# Altium schematic sheet [06] PD_BB_Out.SchDoc("[06] PD_BB_Out")
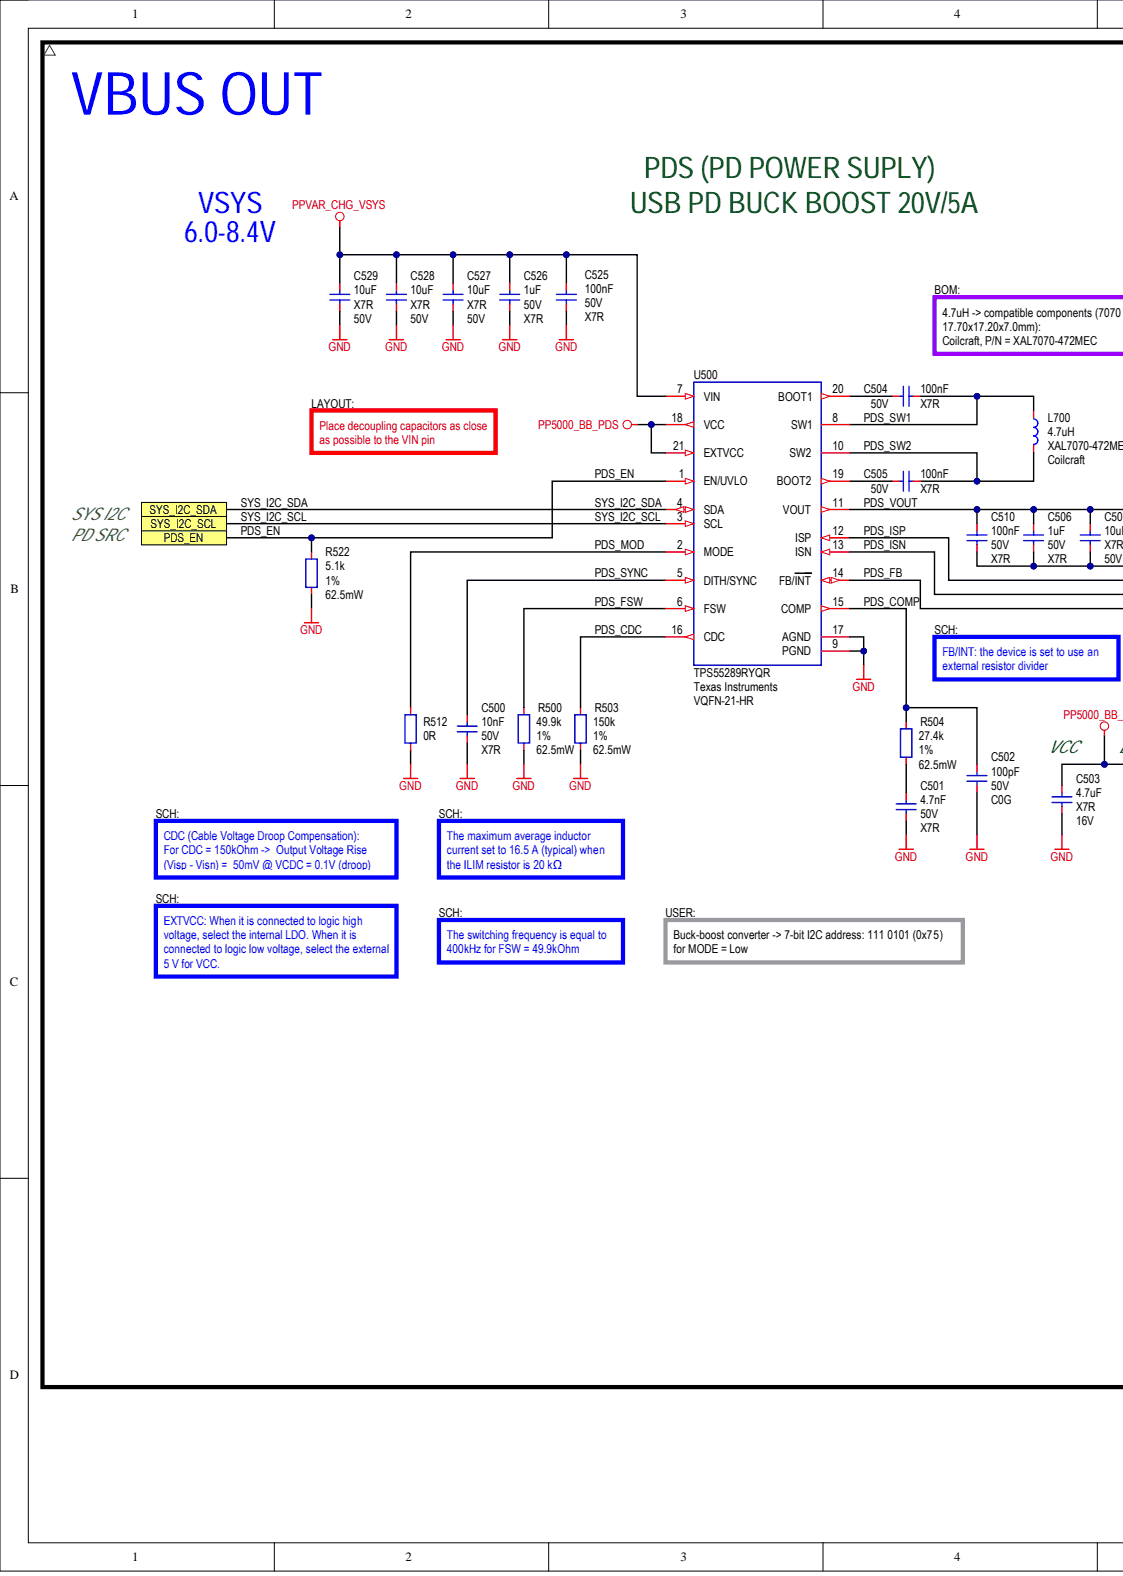
[diagram p0: [06] PD_BB_Out.SchDoc, left]
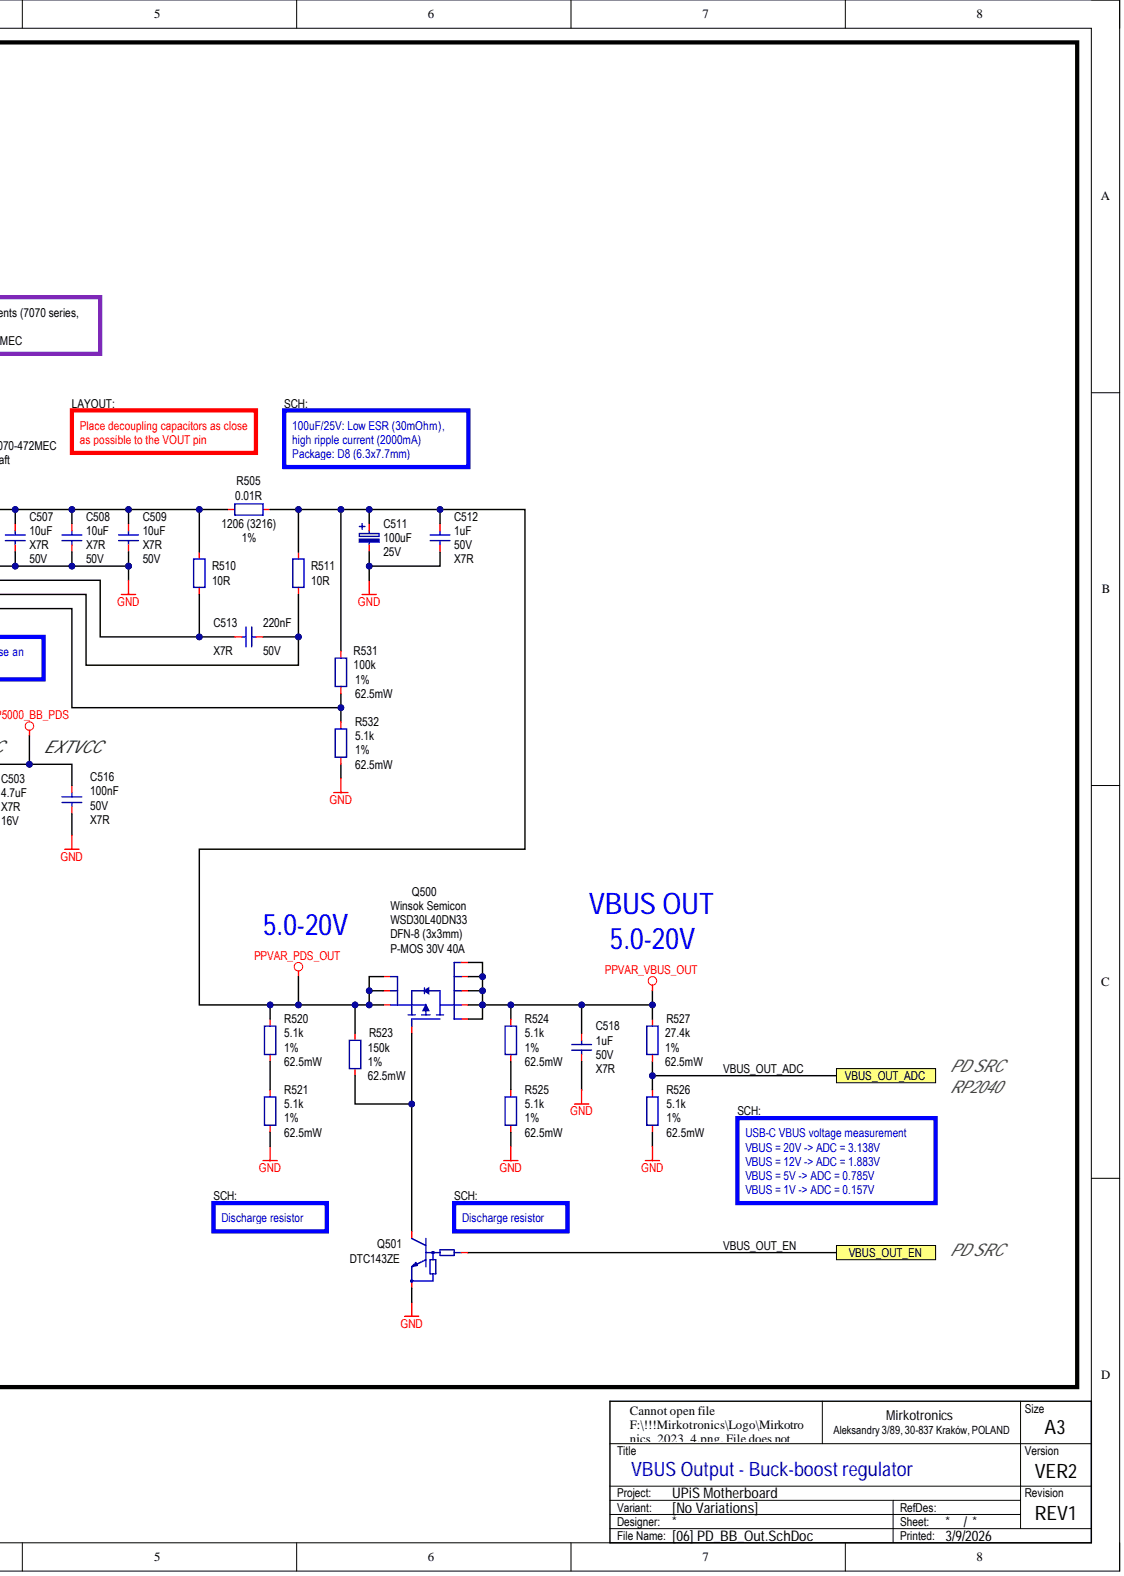
[diagram p1: [06] PD_BB_Out.SchDoc, right]

## Parts
C500  10nF  Dielectric: X7R  Voltage Rating: 50V
C501  4.7nF  Dielectric: X7R  Voltage Rating: 50V
C502  100pF  Dielectric: C0G  Voltage Rating: 50V
C503  4.7uF  Dielectric: X7R  Voltage Rating: 16V
C504  100nF  Dielectric: X7R  Voltage Rating: 50V
C505  100nF  Dielectric: X7R  Voltage Rating: 50V
C506  1uF  Dielectric: X7R  Voltage Rating: 50V
C507  10uF  Dielectric: X7R  Voltage Rating: 50V
C508  10uF  Dielectric: X7R  Voltage Rating: 50V
C509  10uF  Dielectric: X7R  Voltage Rating: 50V
C510  100nF  Dielectric: X7R  Voltage Rating: 50V
C511  100uF  Voltage Rating: 25V
C512  1uF  Dielectric: X7R  Voltage Rating: 50V
C513  220nF  Dielectric: X7R  Voltage Rating: 50V
C516  100nF  Dielectric: X7R  Voltage Rating: 50V
C518  1uF  Dielectric: X7R  Voltage Rating: 50V
C525  100nF  Dielectric: X7R  Voltage Rating: 50V
C526  1uF  Dielectric: X7R  Voltage Rating: 50V
C527  10uF  Dielectric: X7R  Voltage Rating: 50V
C528  10uF  Dielectric: X7R  Voltage Rating: 50V
C529  10uF  Dielectric: X7R  Voltage Rating: 50V
L700  4.7uH  Manufacturer: Coilcraft  MPN: XAL7070-472MEC
Q500  P-MOS 30V 40A  Package (Case): DFN-8 (3x3mm)
Q501  DTC143ZE
R500  49.9k  Tolerance: 1%
R503  150k  Power Rating: 62.5mW  Tolerance: 1%
R504  27.4k  Power Rating: 62.5mW  Tolerance: 1%
R505  0.01R  Package (Case): 1206 (3216)  Tolerance: 1%
R510  10R
R511  10R
R512  0R
R520  5.1k  Power Rating: 62.5mW  Tolerance: 1%
R521  5.1k  Power Rating: 62.5mW  Tolerance: 1%
R522  5.1k  Power Rating: 62.5mW  Tolerance: 1%
R523  150k  Power Rating: 62.5mW  Tolerance: 1%
R524  5.1k  Power Rating: 62.5mW  Tolerance: 1%
R525  5.1k  Power Rating: 62.5mW  Tolerance: 1%
R526  5.1k  Power Rating: 62.5mW  Tolerance: 1%
R527  27.4k  Power Rating: 62.5mW  Tolerance: 1%
R531  100k  Power Rating: 62.5mW  Tolerance: 1%
R532  5.1k  Power Rating: 62.5mW  Tolerance: 1%
U500  TPS55289  Manufacturer: Texas Instruments  MPN: TPS55289RYQR  Package (Case): VQFN-21-HR

## Ports
PDS_EN, SYS_I2C_SCL, SYS_I2C_SDA, VBUS_OUT_ADC, VBUS_OUT_EN

## Nets
GND: C500.1 C501.1 C502.1 C503.1 C506.1 C507.1 C508.1 C509.1 C510.1 C511.1 C512.1 C516.2 C518.1 C525.2 C526.1 C527.1 C528.1 C529.1 Q501.2 R500.2 R503.2 R512.1 R521.1 R522.1 R525.1 R526.1 R532.1 U500.9(PGND) U500.17(AGND)
PP5000_BB_PDS: C503.2 C516.1 U500.18(VCC) U500.21(EXTVCC)
PPVAR_CHG_VSYS: C525.1 C526.2 C527.2 C528.2 C529.2 U500.7(VIN)
PPVAR_PDS_OUT: C511.2 C512.2 Q500.1 Q500.2 Q500.3 R505.2 R511.1 R520.2 R523.1 R531.2
PPVAR_VBUS_OUT: C518.2 Q500.5 Q500.6 Q500.7 Q500.8 Q500.EP R524.2 R527.1
PDS_CDC: R503.1 U500.16(CDC)
PDS_COMP: C502.2 R504.1 U500.15(COMP)
PDS_EN: R522.2 U500.1(EN/UVLO) ; port PDS_EN
PDS_FB: R531.1 R532.2 U500.14(FB/INT)
PDS_FSW: R500.1 U500.6(FSW)
PDS_ISN: C513.1 R511.2 U500.13(ISN)
PDS_ISP: C513.2 R510.2 U500.12(ISP)
PDS_MOD: R512.2 U500.2(MODE)
PDS_SW1: C504.1 L700.1 U500.8(SW1)
PDS_SW2: C505.1 L700.2 U500.10(SW2)
PDS_SYNC: C500.2 U500.5(DITH/SYNC)
PDS_VOUT: C506.2 C507.2 C508.2 C509.2 C510.2 R505.1 R510.1 U500.11(VOUT)
SYS_I2C_SCL: U500.3(SCL) ; port SYS_I2C_SCL
SYS_I2C_SDA: U500.4(SDA) ; port SYS_I2C_SDA
VBUS_OUT_ADC: R526.2 R527.2 ; port VBUS_OUT_ADC
VBUS_OUT_EN: Q501.1 ; port VBUS_OUT_EN
NetC501_2: C501.2 R504.2
NetC504_2: C504.2 U500.20(BOOT1)
NetC505_2: C505.2 U500.19(BOOT2)
NetQ500_4: Q500.4 Q501.3 R523.2
NetR520_1: R520.1 R521.2
NetR524_1: R524.1 R525.2

## Library data of the parts
C500 = CAP-0023: Capacitor; MLCC; GENERIC; TBD; TBD; TBD; TBD; SMD; 0603 (1608);; Manufacturer: YAGEO; MPN: CC0402KRX7R9BB103; Database Table Name: CAPACITOR$; Package (Case): 0402 (1005); Tolerance: 10%; Capacitance: 10nF; Component Kind: Standard; Component Subtype: MLCC; Component Type: Capacitor; Mounting Type: SMD; Operating Temperature: TBD; Supplier 1: LCSC; Supplier 2: Mouser; Supplier 3: DigiKey; Supplier Part Number 1: C60133; Value: 10nF; Library Name: MirFol_db_local.DbLib
C501 = CAP-0023: Capacitor; MLCC; GENERIC; TBD; TBD; TBD; TBD; SMD; 0603 (1608);; Manufacturer: YAGEO; MPN: CC0402KRX7R9BB472; Database Table Name: CAPACITOR$; Package (Case): 0402 (1005); Tolerance: 10%; Capacitance: 4.7nF; Component Kind: Standard; Component Subtype: MLCC; Component Type: Capacitor; Mounting Type: SMD; Operating Temperature: TBD; Supplier 1: LCSC; Supplier 2: Mouser; Supplier 3: DigiKey; Supplier Part Number 1: C106208; Value: 4.7nF; Library Name: MirFol_db_local.DbLib
C502 = CAP-0023: Capacitor; MLCC; GENERIC; TBD; TBD; TBD; TBD; SMD; 0603 (1608);; Manufacturer: Murata Electronics; MPN: GRM1555C1H101JA01D; Database Table Name: CAPACITOR$; Package (Case): 0402 (1005); Tolerance: 5%; Capacitance: 100pF; Component Kind: Standard; Component Subtype: MLCC; Component Type: Capacitor; Mounting Type: SMD; Operating Temperature: TBD; Supplier 1: LCSC; Supplier 2: Mouser; Supplier 3: DigiKey; Supplier Part Number 1: C77177; Value: 100pF; Library Name: MirFol_db_local.DbLib
C503 = CAP-0023: Capacitor; MLCC; GENERIC; TBD; TBD; TBD; TBD; SMD; 0603 (1608);; Manufacturer: Murata Electronics; MPN: GRM188Z71C475KE21D; Component Body: 1.60 x 0.80mm; Database Table Name: CAPACITOR$; Packaging Type: Tape & Reel; Tolerance: 10%; Capacitance: 4.7uF; Component Height: 0.80mm; Component Kind: Standard; Component Subtype: MLCC; Component Type: Capacitor; Features: Standard; ID: CAP-0010; Mounting Type: SMD; Operating Temperature: -55°C to +125°C; Package (Case): 0603 (1608); ROHS Status: RoHS Compliant; Supplier 1: LCSC; Supplier 2: Mouser; Supplier 3: Digikey; Supplier Part Number 1: C389010; Value: 4.7uF; Library Name: MirFol_db_local.DbLib
C504 C505 C510 C516 C525 = CAP-0004: Capacitor; MLCC; 100nF; 16VDC; X7R; 10%; Standard; SMD; 0402 (1005);; Manufacturer: Murata Electronics; MPN: GRM155R71H104KE14D; Component Body: 1.00 x 0.50mm; Database Table Name: CAPACITOR$; Packaging Type: Tape & Reel; Capacitance: 100nF; Component Height: 0.50mm; Component Kind: Standard; Component Subtype: MLCC; Component Type: Capacitor; Features: Standard; ID: CAP-0004; Mounting Type: SMD; Operating Temperature: -55°C to +125°C; Package (Case): 0402 (1005); ROHS Status: RoHS Compliant; Supplier 1: LCSC; Supplier 2: Mouser; Supplier 3: DigiKey; Supplier Part Number 1: C77020; Tolerance: 10%; Value: 100nF; Library Name: MirFol_db_local.DbLib
C506 C512 C518 C526 = CAP-0024: Capacitor; MLCC; GENERIC; TBD; TBD; TBD; TBD; SMD; 0805 (2012);; Manufacturer: Samsung Electro-Mechanics; MPN: CL21B105KBFNNNE; Database Table Name: CAPACITOR$; Tolerance: 10%; Capacitance: 1uF; Component Body: TBD; Component Height: TBD; Component Kind: Standard; Component Subtype: MLCC; Component Type: Capacitor; Features: TBD; ID: CAP-0024; Mounting Type: SMD; Operating Temperature: -55°C to 125°C; Package (Case): 0805 (2012); Packaging Type: TBD; ROHS Status: TBD; Supplier 1: LCSC; Supplier 2: Mouser; Supplier 3: Farnell; Supplier Part Number 1: C28323; Supplier Part Number 2: 187-CL21B105KBFNNNE; Supplier Part Number 3: 3013482; Value: 1uF; Library Name: MirFol_db_local.DbLib
C507-C509 C527-C529 = CAP-0025: Capacitor; MLCC; GENERIC; TBD; TBD; TBD; TBD; SMD; 1206 (3216);; Manufacturer: Samsung Electro-Mechanics; MPN: CL31B106KBHNNNE; Database Table Name: CAPACITOR$; Tolerance: 10%; Capacitance: 10uF; Component Body: TBD; Component Height: TBD; Component Kind: Standard; Component Subtype: MLCC; Component Type: Capacitor; Features: TBD; ID: CAP-0016; Mounting Type: SMD; Operating Temperature: TBD; Package (Case): 1206 (3216); Packaging Type: TBD; ROHS Status: TBD; Supplier 1: LCSC; Supplier 2: Mouser; Supplier 3: DigiKey; Supplier Part Number 1: C89632; Value: 10uF; Library Name: MirFol_db_local.DbLib
C511 = CAP_POL_2P_NP: Capacitor; Polarized;; Manufacturer: Panasonic; MPN: EEH-ZA1E101XP; Component Subtype: Electrolytic; Package (Case): SMD D8 (6.3x7.7mm); Capacitance: 100uF; Component Kind: Standard; Component Type: Capacitor; Mounting Type: SMD; Supplier 1: LCSC; Supplier 2: Mouser; Supplier Part Number 1: C264047; Supplier Part Number 2: 667-EEH-ZA1E101XP; Value: 100uF; Library Name: MirFol_lib.SchLib
C513 = CAP-0023: Capacitor; MLCC; GENERIC; TBD; TBD; TBD; TBD; SMD; 0603 (1608);; Manufacturer: CCTC; MPN: TCC0603X7R224K500CT; Component Body: 1.60 x 0.80mm; Database Table Name: CAPACITOR$; Packaging Type: Tape & Reel; Tolerance: 10%; Capacitance: 220nF; Component Height: 0.80mm; Component Kind: Standard; Component Subtype: MLCC; Component Type: Capacitor; Features: Standard; ID: CAP-0010; Mounting Type: SMD; Operating Temperature: -55°C to +125°C; Package (Case): 0603 (1608); ROHS Status: RoHS Compliant; Supplier 1: LCSC; Supplier 2: Mouser; Supplier 3: Digikey; Supplier Part Number 1: C344195; Value: 220nF; Library Name: MirFol_db_local.DbLib
L700 = IND: Inductor;; Component Subtype: Power Inductor; Package (Case): SMD; Component Kind: Standard; Component Type: Inductor; Mounting Type: SMD; Supplier 1: LCSC; Supplier 2: Mouser; Supplier 3: DigiKey; Supplier Part Number 1: C3911513; Value: 4.7uH; Library Name: MirFol_lib.SchLib
Q500 = TRA_PMOS_SSSGDDDD_EP: Transistor; PMOS;; Manufacturer: Winsok Semicon; MPN: WSD30L40DN33; Component Subtype: P-MOSFET; Component Kind: Standard; Component Type: Transistor; Mounting Type: SMD; Supplier 1: LCSC; Supplier 2: Mouser; Supplier 3: Digikey; Supplier Part Number 1: C148390; Value: P-MOS 30V 40A; Library Name: Pineberry_Lib.SchLib
Q501 = TRA_BJT_NPN_DIG_BEC: Transistor; BJT; NPN; Digital;; Manufacturer: Jiangsu Changjing Electronics Technology Co., Ltd.; Component Subtype: NPN Transistor; Package (Case): SOT-523; Component Kind: Standard; Component Type: Transistor; Mounting Type: SMD; MPN: DTC143ZE; Supplier 1: LCSC; Supplier 2: Mouser; Supplier 3: DigiKey; Supplier Part Number 1: C78593; Value: DTC143ZE; Library Name: MirFol_lib.SchLib
R500 = RES-0003: Resistor; Thick Film; 1k; 1%; 62.5mW; 100PPM; 50V; Standard; SMD; 0402 (1005);; Manufacturer: Yageo Corporation; MPN: RC0402FR-0749K9L; Component Body: 1.00 x 0.50mm; Database Table Name: RESISTOR$; Packaging Type: Tape & Reel; Component Height: 0.35mm; Component Kind: Standard; Component Subtype: Thick Film; Component Type: Resistor; Features: Standard; ID: RES-0003; Mounting Type: SMD; Operating Temperature: -55°C to +155°C; Package (Case): 0402 (1005); Power Rating: 62.5mW; Resistance: 49.9k; ROHS Status: RoHS Compliant; Supplier 1: LCSC; Supplier 2: Mouser; Supplier 3: Digikey; Supplier Part Number 1: C137969; TCR: 100PPM; Value: 49.9k; Voltage Rating: 50V; Library Name: MirFol_db_local.DbLib
R503 R523 = RES-0003: Resistor; Thick Film; 1k; 1%; 62.5mW; 100PPM; 50V; Standard; SMD; 0402 (1005);; Manufacturer: Yageo Corporation; MPN: RC0402FR-07150KL; Component Body: 1.00 x 0.50mm; Database Table Name: RESISTOR$; Packaging Type: Tape & Reel; Component Height: 0.35mm; Component Kind: Standard; Component Subtype: Thick Film; Component Type: Resistor; Features: Standard; ID: RES-0003; Mounting Type: SMD; Operating Temperature: -55°C to +155°C; Package (Case): 0402 (1005); Resistance: 150k; ROHS Status: RoHS Compliant; Supplier 1: LCSC; Supplier 2: Mouser; Supplier 3: Digikey; Supplier Part Number 1: C93947; TCR: 100PPM; Value: 150k; Voltage Rating: 50V; Library Name: MirFol_db_local.DbLib
R504 R527 = RES-0003: Resistor; Thick Film; 1k; 1%; 62.5mW; 100PPM; 50V; Standard; SMD; 0402 (1005);; Manufacturer: Yageo Corporation; MPN: RC0402FR-0727K4L; Component Body: 1.00 x 0.50mm; Database Table Name: RESISTOR$; Packaging Type: Tape & Reel; Component Height: 0.35mm; Component Kind: Standard; Component Subtype: Thick Film; Component Type: Resistor; Features: Standard; ID: RES-0003; Mounting Type: SMD; Operating Temperature: -55°C to +155°C; Package (Case): 0402 (1005); Resistance: 27.4k; ROHS Status: RoHS Compliant; Supplier 1: LCSC; Supplier 2: Mouser; Supplier 3: Digikey; Supplier Part Number 1: C273730; TCR: 100PPM; Value: 27.4k; Voltage Rating: 50V; Library Name: MirFol_db_local.DbLib
R505 = RES-0060: Resistor; Thick Film; GENERIC; TBD; TBD; TBD; TBD; TBD; SMD; 2512 (6432);; Manufacturer: Yageo; MPN: PE1206FKE470R01Z; Database Table Name: RESISTOR$; Packaging Type: Tape & Reel; Power Rating: 1W; TCR: 50PPM; Component Body: TBD; Component Height: TBD; Component Kind: Standard; Component Subtype: Thick Film; Component Type: Resistor; Features: TBD; ID: RES-0060; Mounting Type: SMD; Operating Temperature: TBD; Resistance: 0.01R; ROHS Status: RoHS Compliant; Supplier 1: LCSC; Supplier 2: Mouser; Supplier 3: Digikey; Supplier Part Number 1: C364375; Supplier Part Number 2: 603-1206FRE470R01Z1; Supplier Part Number 3: 13-PA1206FRE470R01ZTR-ND; Value: 0.01R; Voltage Rating: TBD; Library Name: MirFol_db_local.DbLib
R510 R511 = RES-0003: Resistor; Thick Film; 1k; 1%; 62.5mW; 100PPM; 50V; Standard; SMD; 0402 (1005);; Manufacturer: Yageo Corporation; MPN: RC0402FR-0710RL; Component Body: 1.00 x 0.50mm; Database Table Name: RESISTOR$; Packaging Type: Tape & Reel; Component Height: 0.35mm; Component Kind: Standard; Component Subtype: Thick Film; Component Type: Resistor; Features: Standard; ID: RES-0003; Mounting Type: SMD; Operating Temperature: -55°C to +155°C; Package (Case): 0402 (1005); Power Rating: 62.5mW; Resistance: 10R; ROHS Status: RoHS Compliant; Supplier 1: LCSC; Supplier 2: Mouser; Supplier 3: Digikey; Supplier Part Number 1: C138066; TCR: 100PPM; Tolerance: 1%; Value: 10R; Voltage Rating: 50V; Library Name: MirFol_db_local.DbLib
R512 = RES-0057: Resistor; Thick Film; 0R; 1%; 62.5mW; 100PPM; 50V; Standard; SMD; 0402 (1005);; Manufacturer: YAGEO; MPN: RC0402FR-070RL; Component Body: 1.00 x 0.50mm; Database Table Name: RESISTOR$; Packaging Type: Tape & Reel; Component Height: TBD; Component Kind: Standard; Component Subtype: Thick Film; Component Type: Resistor; Features: Standard; ID: RES-0057; Mounting Type: SMD; Operating Temperature: -55°C to +155°C; Package (Case): 0402 (1005); Power Rating: 62.5mW; Resistance: 0R; ROHS Status: RoHS Compliant; Supplier 1: LCSC; Supplier 2: Mouser; Supplier 3: Digikey; Supplier Part Number 1: C106231; Supplier Part Number 2: 603-RC0402FR-070RL; Supplier Part Number 3: 311-0.0LRTR-ND; TCR: 100PPM; Tolerance: 1%; Value: 0R; Voltage Rating: 50V; Library Name: MirFol_db_local.DbLib
R520-R522 R524-R526 R532 = RES-0058: Resistor; Thick Film; GENERIC; TBD; TBD; TBD; TBD; TBD; SMD; 0402 (1005);; Manufacturer: YAGEO; MPN: RC0402FR-075K1L; Component Body: 1.00 x 0.50mm; Database Table Name: RESISTOR$; Packaging Type: Tape & Reel; TCR: 100PPM; Voltage Rating: 50V; Component Height: 0.35mm; Component Kind: Standard; Component Subtype: Thick Film; Component Type: Resistor; Features: Standard; ID: RES-0058; Mounting Type: SMD; Operating Temperature: -55°C to +155°C; Package (Case): 0402 (1005); Resistance: 5.1k; ROHS Status: RoHS Compliant; Supplier 1: LCSC; Supplier 2: Mouser; Supplier 3: Digikey; Supplier Part Number 1: C105872; Supplier Part Number 2: 603-RC0402FR-075K1L; Supplier Part Number 3: 311-5.10KLRTR-ND; Value: 5.1k; Library Name: MirFol_db_local.DbLib
R531 = RES-0005: Resistor; Thick Film; 100k; 1%; 62.5mW; 100PPM; 50V; Standard; SMD; 0402 (1005);; Manufacturer: YAGEO; MPN: RC0402FR-07100KL; Component Body: 1.00 x 0.50mm; Database Table Name: RESISTOR$; Packaging Type: Tape & Reel; Component Height: 0.35mm; Component Kind: Standard; Component Subtype: Thick Film; Component Type: Resistor; Features: Standard; ID: RES-0005; Mounting Type: SMD; Operating Temperature: -55°C to +155°C; Package (Case): 0402 (1005); Resistance: 100k; ROHS Status: RoHS Compliant; Supplier 1: LCSC; Supplier 2: Mouser; Supplier 3: Digikey; Supplier Part Number 1: C60491; Supplier Part Number 2: 603-RC0402FR-07100KL; Supplier Part Number 3: 311-100KLRTR-ND; TCR: 100PPM; Value: 100k; Voltage Rating: 50V; Library Name: MirFol_db_local.DbLib
U500 = ICC_TXI_TPS55289_VQFN21-HR: IC; Buck-boost converter;; Component Kind: Standard; Component Subtype: Buck-boost converter; Component Type: IC; Mounting Type: SMD; Supplier 1: LCSC; Supplier Part Number 1: C5942077; Value: TPS55289; Library Name: Pineberry_Lib.SchLib
